# Altium schematic sheet STC8A8K核心板V2.1.SchDoc
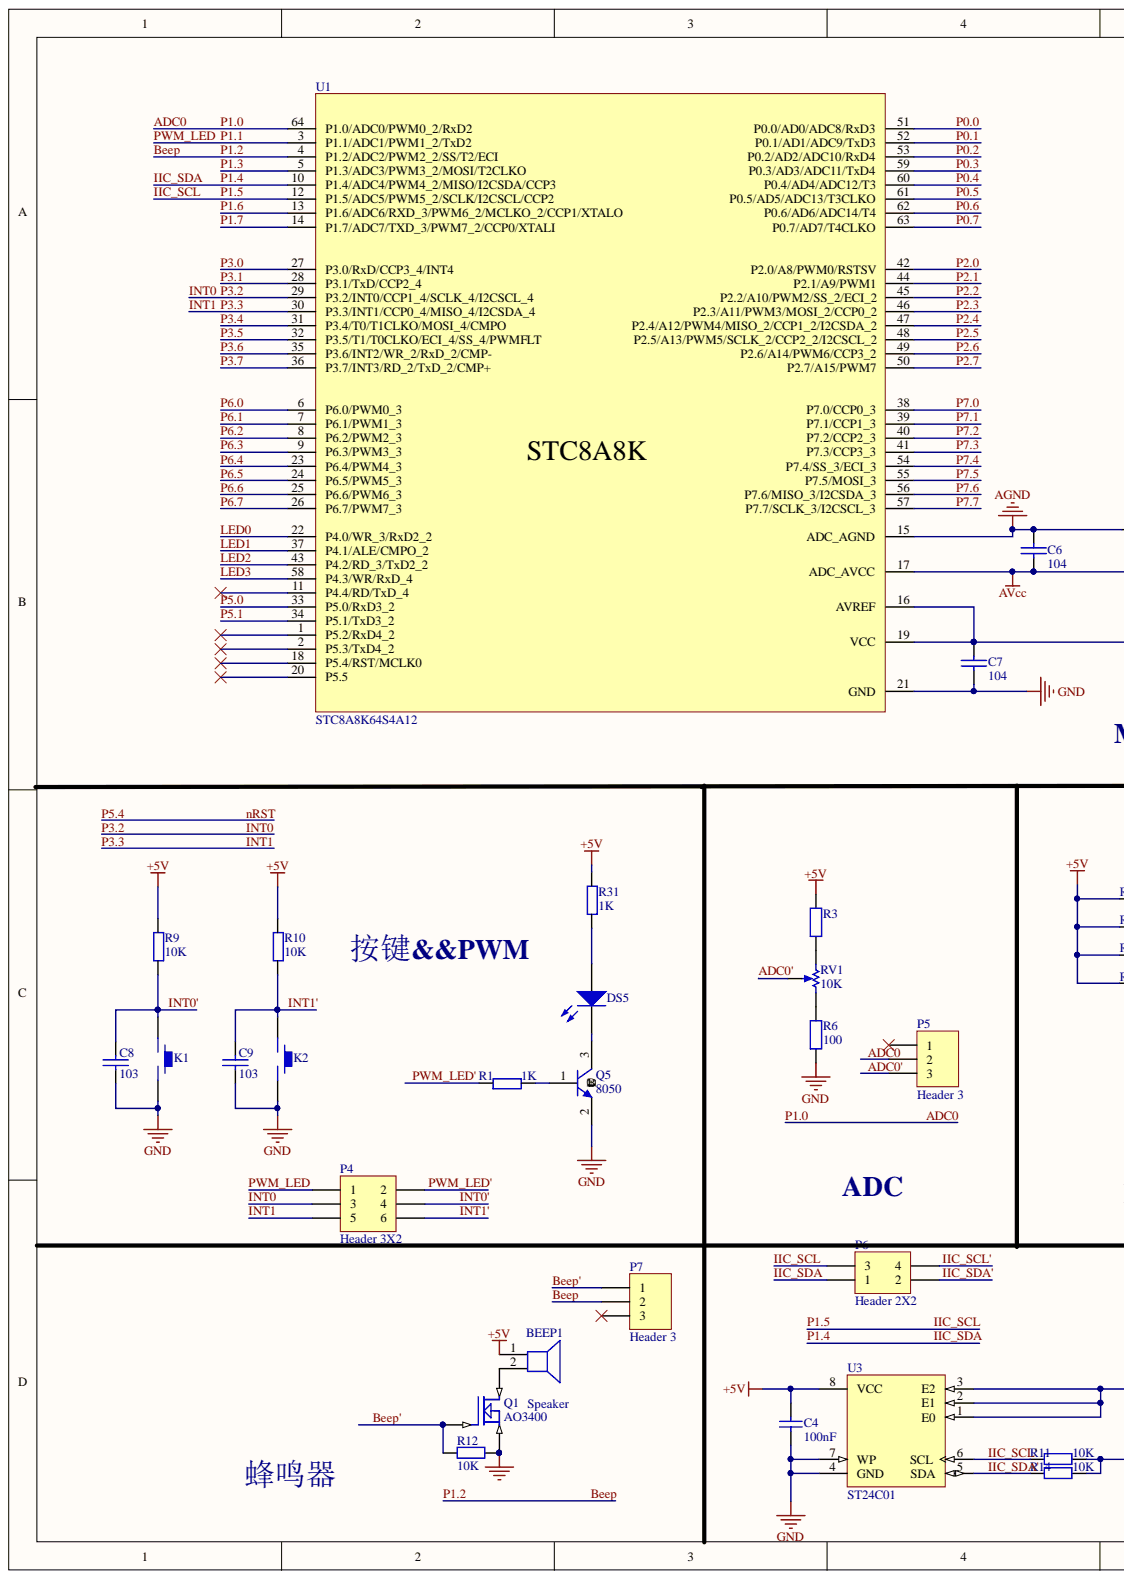
[diagram p0: STC8A8K核心板V2.1.SchDoc, left]
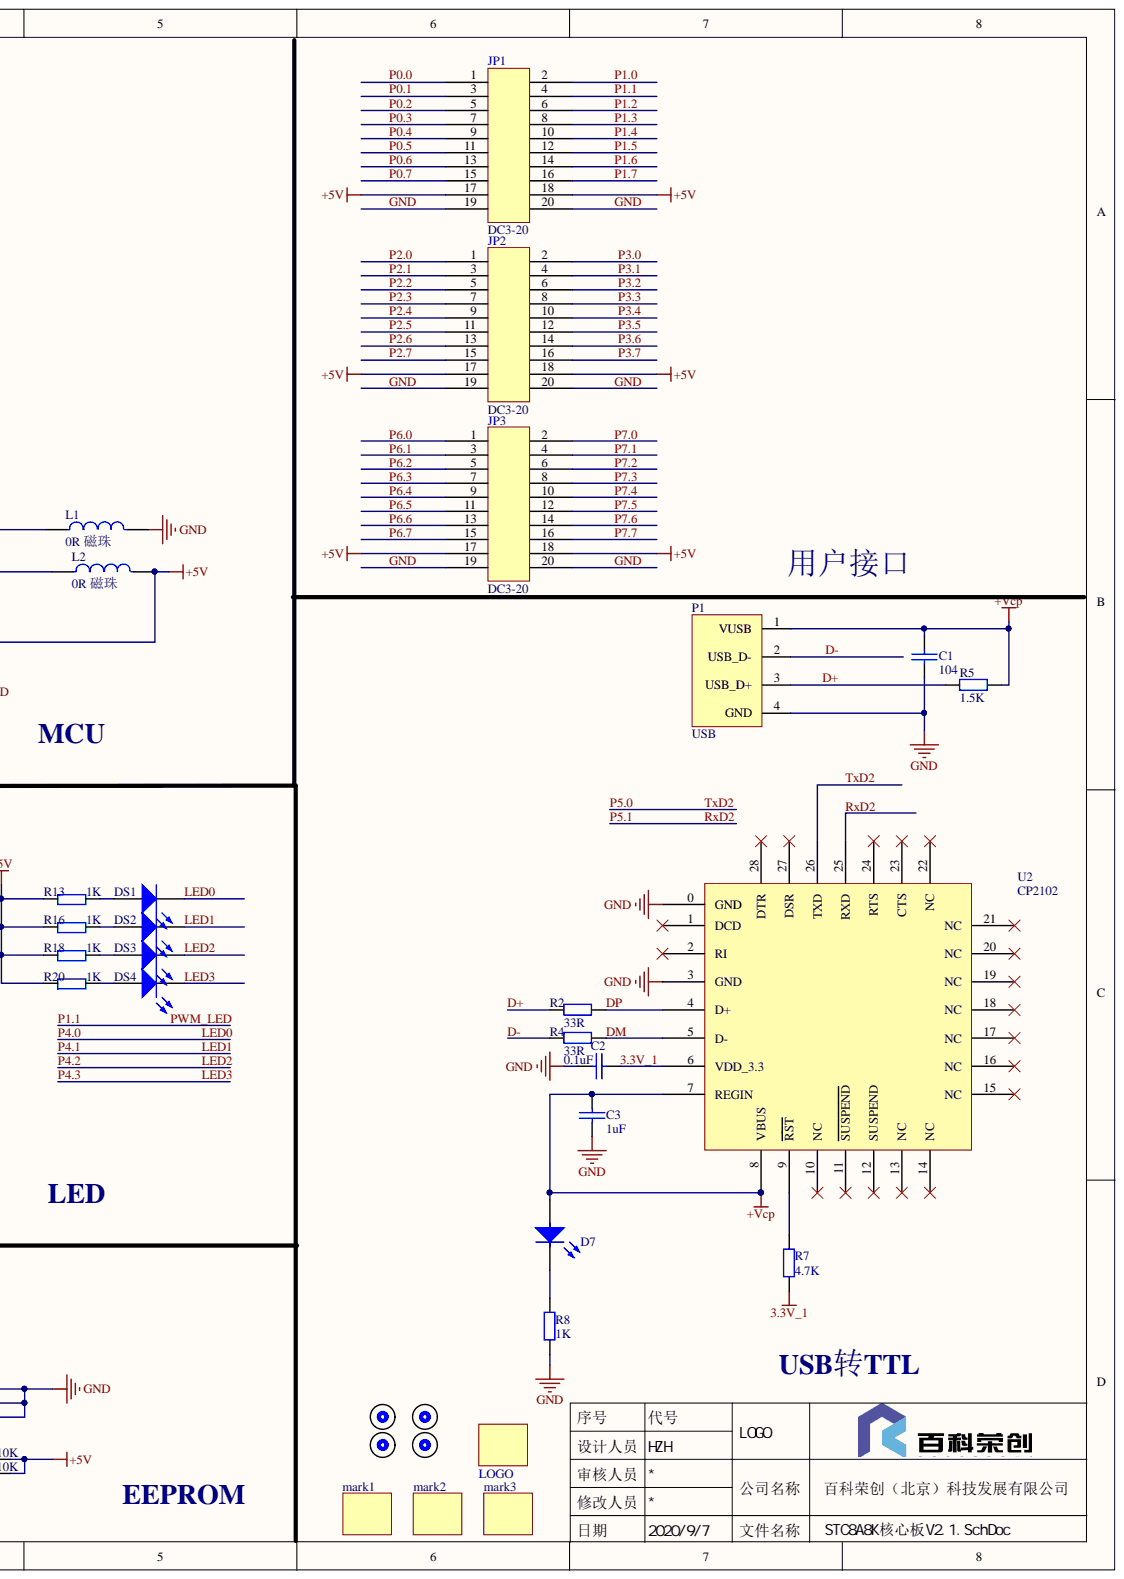
[diagram p1: STC8A8K核心板V2.1.SchDoc, right]

## Parts
*1  LOGO  [LOGO_H_180308]
BEEP1  Speaker  [蜂鸣器]
C1  104  [C0603]
C2  0.1uF  [C0603]
C3  1uF  [C0603]
C4  100nF  [C0603]
C6  104  [C0603]
C7  104  [C0603]
C8  103  [C0603]
C9  103  [C0603]
D7  LED  [LED_0603]  (value not drawn)
DS1  红色  [LED_0603]  (value not drawn)
DS2  绿  [LED_0603]  (value not drawn)
DS3  蓝  [LED_0603]  (value not drawn)
DS4  黄  [LED_0603]  (value not drawn)
DS5  红色  [LED_3528]  (value not drawn)
JP1  DC3-20  [DC3-20]
JP2  DC3-20  [DC3-20]
JP3  DC3-20  [DC3-20]
K1  KEY_M  [KEY6*6]  (value not drawn)
K2  KEY_M  [KEY6*6]  (value not drawn)
L1  0R 磁珠  [R0805]
L2  0R 磁珠  [R0805]
mark1  MARK  [PCB_MARK 2]  (value not drawn)
mark2  MARK  [PCB_MARK 2]  (value not drawn)
mark3  MARK  [PCB_MARK 2]  (value not drawn)
P1  USB  [USB_B1-180]
P4  Header 3X2  [HDR2X3]
P5  Header 3  [HDR1X3]
P6  Header 2X2  [HDR2X2]
P7  Header 3  [HDR1X3]
Q1  AO3400  [SOT-23]
Q5  8050  [SOT-23]
R1  1K  [R0603]
R2  33R  [R0603]
R3  100  [0805]  (value not drawn)
R4  33R  [R0603]
R5  1.5K  [R0603]
R6  100  [0805]
R7  4.7K  [R0603]
R8  1K  [R0603]
R9  10K  [R0603]
R10  10K  [R0603]
R11  10K  [R0603]
R12  10K  [R0603]
R13  1K  [R0603]
R14  10K  [R0603]
R16  1K  [R0603]
R18  1K  [R0603]
R20  1K  [R0603]
R31  1K  [R0603]
RV1  10K  [3386]
U1  STC8A8K64S4A12  [LQFP64S]
U2  CP2102  [QFN-28]
U3  ST24C01  [SOP-8]
定位孔1  定位孔  [边孔]  (value not drawn)
定位孔2  定位孔  [边孔]  (value not drawn)
定位孔3  定位孔  [边孔]  (value not drawn)
定位孔4  定位孔  [边孔]  (value not drawn)

## Nets
+5V: BEEP1.1 C4.2 C7.1 JP1.17 JP1.18 JP2.17 JP2.18 JP3.17 JP3.18 L2.1 R3.2 R9.2 R10.2 R11.2 R13.1 R14.2 R16.1 R18.1 R20.1 R31.2 U1.16(AVREF) U1.19(VCC) U3.8(VCC)
+VCP: C1.2 C3.2 D7.1 P1.1(VUSB) R5.1 U2.7(REGIN) U2.8(VBUS)
AGND: C6.2 L1.2 U1.15(ADC_AGND)
AVCC: C6.1 L2.2 U1.17(ADC_AVCC)
3.3V_1: C2.2 R7.2 U2.6(VDD_3.3)
ADC0: JP1.2 P5.2 U1.64(P1.0/ADC0/PWM0_2/RxD2)
ADC0': P5.3 RV1.2
BEEP: JP1.6 P7.2 U1.4(P1.2/ADC2/PWM2_2/SS/T2/ECI)
BEEP': P7.1 Q1.1 R12.2
D+: P1.3(USB_D+) R2.1 R5.2
D-: P1.2(USB_D-) R4.1
DM: R4.2 U2.5(D-)
DP: R2.2 U2.4(D+)
GND: C1.1 C2.1 C3.1 C4.1 C7.2 C8.2 C9.2 JP1.19 JP1.20 JP2.19 JP2.20 JP3.19 JP3.20 K1.2 K2.2 L1.1 P1.4(GND) Q1.2 Q5.2 R6.1 R8.2 R12.1 U1.21(GND) U2.0(GND) U2.3(GND) U3.1(E0) U3.2(E1) U3.3(E2) U3.4(GND) U3.7(WP)
IIC_SCL: JP1.12 P6.3 U1.12(P1.5/ADC5/PWM5_2/SCLK/I2CSCL/CCP2)
IIC_SCL': P6.4 R11.1 U3.6(SCL)
IIC_SDA: JP1.10 P6.1 U1.10(P1.4/ADC4/PWM4_2/MISO/I2CSDA/CCP3)
IIC_SDA': P6.2 R14.1 U3.5(SDA)
INT0: JP2.6 P4.3 U1.29(P3.2/INT0/CCP1_4/SCLK_4/I2CSCL_4)
INT0': C8.1 K1.1 P4.4 R9.1
INT1: JP2.8 P4.5 U1.30(P3.3/INT1/CCP0_4/MISO_4/I2CSDA_4)
INT1': C9.1 K2.1 P4.6 R10.1
LED0: DS1.2 U1.22(P4.0/WR_3/RxD2_2)
LED1: DS2.2 U1.37(P4.1/ALE/CMPO_2)
LED2: DS3.2 U1.43(P4.2/RD_3/TxD2_2)
LED3: DS4.2 U1.58(P4.3/WR/RxD_4)
P0.0: JP1.1 U1.51(P0.0/AD0/ADC8/RxD3)
P0.1: JP1.3 U1.52(P0.1/AD1/ADC9/TxD3)
P0.2: JP1.5 U1.53(P0.2/AD2/ADC10/RxD4)
P0.3: JP1.7 U1.59(P0.3/AD3/ADC11/TxD4)
P0.4: JP1.9 U1.60(P0.4/AD4/ADC12/T3)
P0.5: JP1.11 U1.61(P0.5/AD5/ADC13/T3CLKO)
P0.6: JP1.13 U1.62(P0.6/AD6/ADC14/T4)
P0.7: JP1.15 U1.63(P0.7/AD7/T4CLKO)
P1.1: JP1.4 P4.1 U1.3(P1.1/ADC1/PWM1_2/TxD2)
P1.3: JP1.8 U1.5(P1.3/ADC3/PWM3_2/MOSI/T2CLKO)
P1.6: JP1.14 U1.13(P1.6/ADC6/RXD_3/PWM6_2/MCLKO_2/CCP1/XTALO)
P1.7: JP1.16 U1.14(P1.7/ADC7/TXD_3/PWM7_2/CCP0/XTALI)
P2.0: JP2.1 U1.42(P2.0/A8/PWM0/RSTSV)
P2.1: JP2.3 U1.44(P2.1/A9/PWM1)
P2.2: JP2.5 U1.45(P2.2/A10/PWM2/SS_2/ECI_2)
P2.3: JP2.7 U1.46(P2.3/A11/PWM3/MOSI_2/CCP0_2)
P2.4: JP2.9 U1.47(P2.4/A12/PWM4/MISO_2/CCP1_2/I2CSDA_2)
P2.5: JP2.11 U1.48(P2.5/A13/PWM5/SCLK_2/CCP2_2/I2CSCL_2)
P2.6: JP2.13 U1.49(P2.6/A14/PWM6/CCP3_2)
P2.7: JP2.15 U1.50(P2.7/A15/PWM7)
P3.0: JP2.2 U1.27(P3.0/RxD/CCP3_4/INT4)
P3.1: JP2.4 U1.28(P3.1/TxD/CCP2_4)
P3.4: JP2.10 U1.31(P3.4/T0/T1CLKO/MOSI_4/CMPO)
P3.5: JP2.12 U1.32(P3.5/T1/T0CLKO/ECI_4/SS_4/PWMFLT)
P3.6: JP2.14 U1.35(P3.6/INT2/WR_2/RxD_2/CMP-)
P3.7: JP2.16 U1.36(P3.7/INT3/RD_2/TxD_2/CMP+)
P5.0: U1.33(P5.0/RxD3_2) U2.26(TXD)
P5.1: U1.34(P5.1/TxD3_2) U2.25(RXD)
P6.0: JP3.1 U1.6(P6.0/PWM0_3)
P6.1: JP3.3 U1.7(P6.1/PWM1_3)
P6.2: JP3.5 U1.8(P6.2/PWM2_3)
P6.3: JP3.7 U1.9(P6.3/PWM3_3)
P6.4: JP3.9 U1.23(P6.4/PWM4_3)
P6.5: JP3.11 U1.24(P6.5/PWM5_3)
P6.6: JP3.13 U1.25(P6.6/PWM6_3)
P6.7: JP3.15 U1.26(P6.7/PWM7_3)
P7.0: JP3.2 U1.38(P7.0/CCP0_3)
P7.1: JP3.4 U1.39(P7.1/CCP1_3)
P7.2: JP3.6 U1.40(P7.2/CCP2_3)
P7.3: JP3.8 U1.41(P7.3/CCP3_3)
P7.4: JP3.10 U1.54(P7.4/SS_3/ECI_3)
P7.5: JP3.12 U1.55(P7.5/MOSI_3)
P7.6: JP3.14 U1.56(P7.6/MISO_3/I2CSDA_3)
P7.7: JP3.16 U1.57(P7.7/SCLK_3/I2CSCL_3)
PWM_LED': P4.2 R1.1
NetBEEP1_2: BEEP1.2 Q1.3
NetD7_2: D7.2 R8.1
NetDS1_1: DS1.1 R13.2
NetDS2_1: DS2.1 R16.2
NetDS3_1: DS3.1 R18.2
NetDS4_1: DS4.1 R20.2
NetDS5_1: DS5.1 R31.1
NetDS5_2: DS5.2 Q5.3
NetQ5_1: Q5.1 R1.2
NetR3_1: R3.1 RV1.1
NetR6_2: R6.2 RV1.3
NetR7_1: R7.1 U2.9(RST)
NRST:

Not connected: P5 1; P7 3; U1 1(P5.2/RxD4_2) 2(P5.3/TxD4_2) 11(P4.4/RD/TxD_4) 18(P5.4/RST/MCLK0) 20(P5.5); U2 1(DCD) 2(RI) 10(NC) 11(SUSPEND) 12(SUSPEND) 13(NC) 14(NC) 15(NC) 16(NC) 17(NC) 18(NC) 19(NC) 20(NC) 21(NC) 22(NC) 23(CTS) 24(RTS) 27(DSR) 28(DTR)

## Library data of the parts
BEEP1 = Speaker: Loudspeaker; Component Kind: Standard; LatestRevisionDate: 17-Jul-2002; LatestRevisionNote: Re-released for DXP Platform.; PackageReference: PIN2; Published: 24-Mar-1999; Publisher: Altium Limited
C1 = CAP: Capacitor; Component Kind: Standard
C2 C3 C6-C9 = CAP: Component Kind: Standard; Library Name: 通用原理图.SchLib
  C2 C3 C6: : 0.1uF
  C7-C9: : 103
C4 = Cap: Capacitor; Value: 0.1uF; Component Kind: Standard; LatestRevisionDate: 17-Jul-2002; LatestRevisionNote: Re-released for DXP Platform.; Library Name: Miscellaneous Devices.IntLib; PackageDescription: Radial Cap, Thru-Hole; 2 Leads; 0.3 in Pin Spacing; PackageReference: RAD-0.3; Published: 8-Jun-2000; Signal Integrity: Cap; Simulation: CAP; Publisher: Altium Limited
DS1-DS5 = LED2: Typical RED, GREEN, YELLOW, AMBER GaAs LED; Component Kind: Standard; LatestRevisionDate: 17-Jul-2002; LatestRevisionNote: Re-released for DXP Platform.; Library Name: WarShip V3 STM32103封装库.IntLib; PackageDocument: Feb-2002; PackageReference: 3.2X1.6X1.1; Published: 8-Jun-2000; Simulation: LED2; Publisher: Altium Limited
L1 L2 = 电感: : 10uH; Component Kind: Standard; PCB3D: Model Name; Library Name: 通用原理图.SchLib
mark1-mark3 = LOGO: Component Kind: Standard; Library Name: bkrcy.SchLib
P1 = USB_mini: Component Kind: Standard; Library Name: 通用原理图_1.SchLib
P4 = Header 3X2: Header, 3-Pin, Dual row; Component Kind: Standard; LatestRevisionDate: 17-Jul-2002; LatestRevisionNote: Re-released for DXP Platform.; Library Name: Miscellaneous Connectors.IntLib; Signal Integrity: Connector; Publisher: Altium Limited
P5 P7 = Header 3: Header, 3-Pin; Component Kind: Standard; LatestRevisionDate: 17-Jul-2002; LatestRevisionNote: Re-released for DXP Platform.; Library Name: Miscellaneous Connectors.IntLib; Signal Integrity: Connector; Publisher: Altium Limited
P6 = Header 2X2: Header, 2-Pin, Dual row; Component Kind: Standard; LatestRevisionDate: 17-Jul-2002; LatestRevisionNote: Re-released for DXP Platform.; Library Name: Miscellaneous Connectors.IntLib; Signal Integrity: Connector; Publisher: Altium Limited
Q1 = MOSFET N: Component Kind: Standard; Library Name: Miscellaneous Devices.SCHLIB
Q5 = NPN: NPN Bipolar Transistor; Code_JEDEC: TO-226-AA; Component Kind: Standard; LatestRevisionDate: 17-Jul-2002; LatestRevisionNote: Re-released for DXP Platform.; Library Name: Miscellaneous Devices.IntLib; PackageDescription: TO, Flat Index; 3 In-Line, Axial Leads; Body Dia. 4.8mm; Leads 0.55 x 0.51 mm (max); PackageReference: TO-226-AA; Published: 8-Jun-2000; Signal Integrity: NPN; Simulation: NPN; Publisher: Altium Limited
R1 R9 R10 R13 R16 R18 R20 R31 = R: Component Kind: Standard; Library Name: WarShip V3 STM32103封装库.IntLib
R2 R4 R8 = Res2: Resistor; Component Kind: Standard; LatestRevisionDate: 17-Jul-2002; LatestRevisionNote: Re-released for DXP Platform.; Library Name: Miscellaneous Devices.IntLib; PackageReference: AXIAL-0.4; Published: 8-Jun-2000; Signal Integrity: Res; Simulation: RESISTOR; Publisher: Altium Limited
  R2 R4: Value: 33R
  R8: PackageDescription: Axial Device, Thru-Hole; 2 Leads; 0.4 in Pin Spacing; Value: 1K
R3 R6 = RES: Component Kind: Standard; Library Name: 通用原理图.SchLib
  R6: value: 100
R5 = Res2: Resistor; Value: 33R; Component Kind: Standard; LatestRevisionDate: 17-Jul-2002; LatestRevisionNote: Re-released for DXP Platform.; Library Name: Miscellaneous Devices.IntLib; PackageReference: AXIAL-0.4; Published: 8-Jun-2000; Signal Integrity: Res; Simulation: RESISTOR; Publisher: Altium Limited
R7 R12 = Res2: Resistor; Value: 47K; Component Kind: Standard; LatestRevisionDate: 17-Jul-2002; LatestRevisionNote: Re-released for DXP Platform.; Library Name: Miscellaneous Devices.IntLib; PackageDescription: Axial Device, Thru-Hole; 2 Leads; 0.4 in Pin Spacing; PackageReference: AXIAL-0.4; Published: 8-Jun-2000; Signal Integrity: Res; Simulation: RESISTOR; Publisher: Altium Limited
R11 R14 = Res2: Resistor; Value: 1K; Component Kind: Standard; LatestRevisionDate: 17-Jul-2002; LatestRevisionNote: Re-released for DXP Platform.; Library Name: Miscellaneous Devices.IntLib; PackageDescription: Axial Device, Thru-Hole; 2 Leads; 0.4 in Pin Spacing; PackageReference: AXIAL-0.4; Published: 8-Jun-2000; Signal Integrity: Res; Simulation: RESISTOR; Publisher: Altium Limited
RV1 = RPot: 精密可调电阻; Set Position: 0.5; Sim Note: Enter the Resistance value and the set point into parameter fields.; Component Kind: Standard; LatestRevisionDate: 17-Jul-2002; LatestRevisionNote: Re-released for DXP Platform.; PackageReference: VR5; Published: 8-Jun-2000; Value: 10K; Library Name: Fszhang.IntLib; Publisher: Altium Limited
*1 = LOGO: Component Kind: Standard; Library Name: bkrcy.SchLib
D7 = LED: Component Kind: Standard; Library Name: 通用原理图.SchLib
JP1-JP3 = DC3-20: Component Kind: Standard; Library Name: 通用原理图.SchLib
K1 K2 = KEY_M: Component Kind: Standard; Library Name: WarShip V3 STM32103封装库.IntLib
U1 = STC8A8K64S4A12: Component Kind: Standard; Library Name: 通用原理图.SchLib
U2 = CP2102: Component Kind: Standard; Library Name: bkrcy.SchLib
U3 = ST24C01: Component Kind: Standard; Library Name: 通用原理图.SchLib
定位孔1-定位孔4 = 定位孔: Component Kind: Standard; Library Name: 通用原理图.SchLib
